# Altium schematic sheet 06_HDMI_I.SchDoc
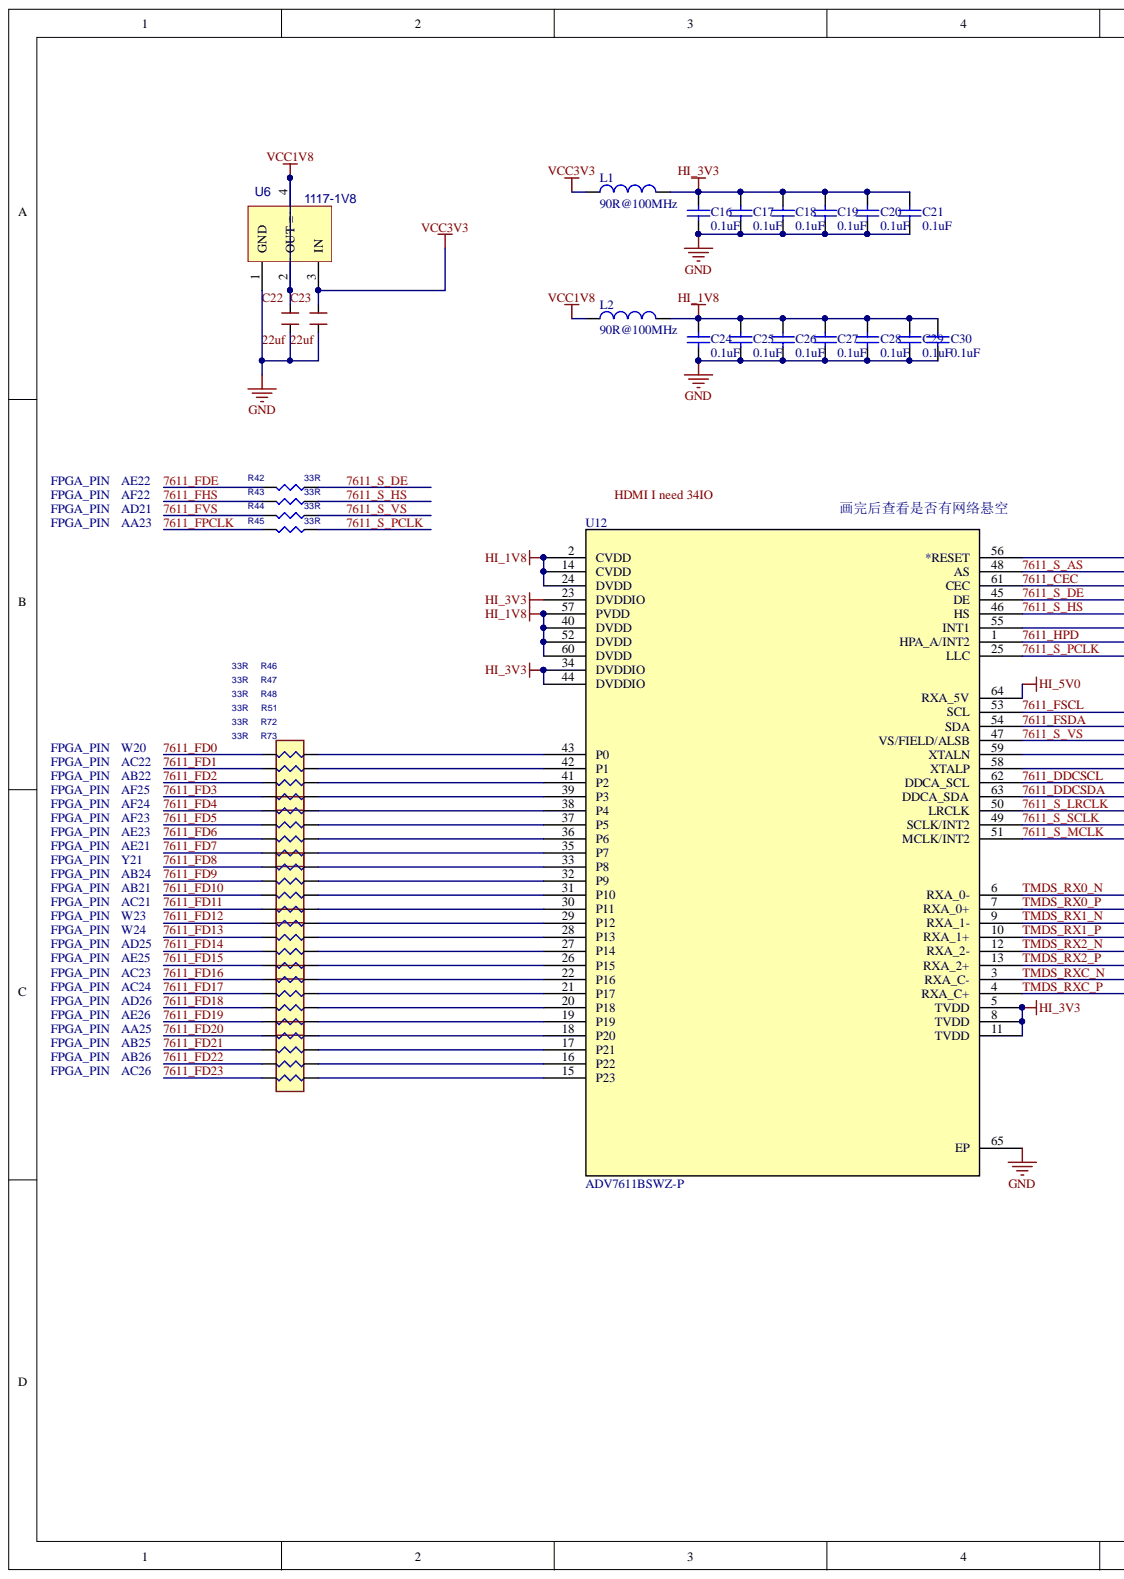
[diagram p0: 06_HDMI_I.SchDoc, left]
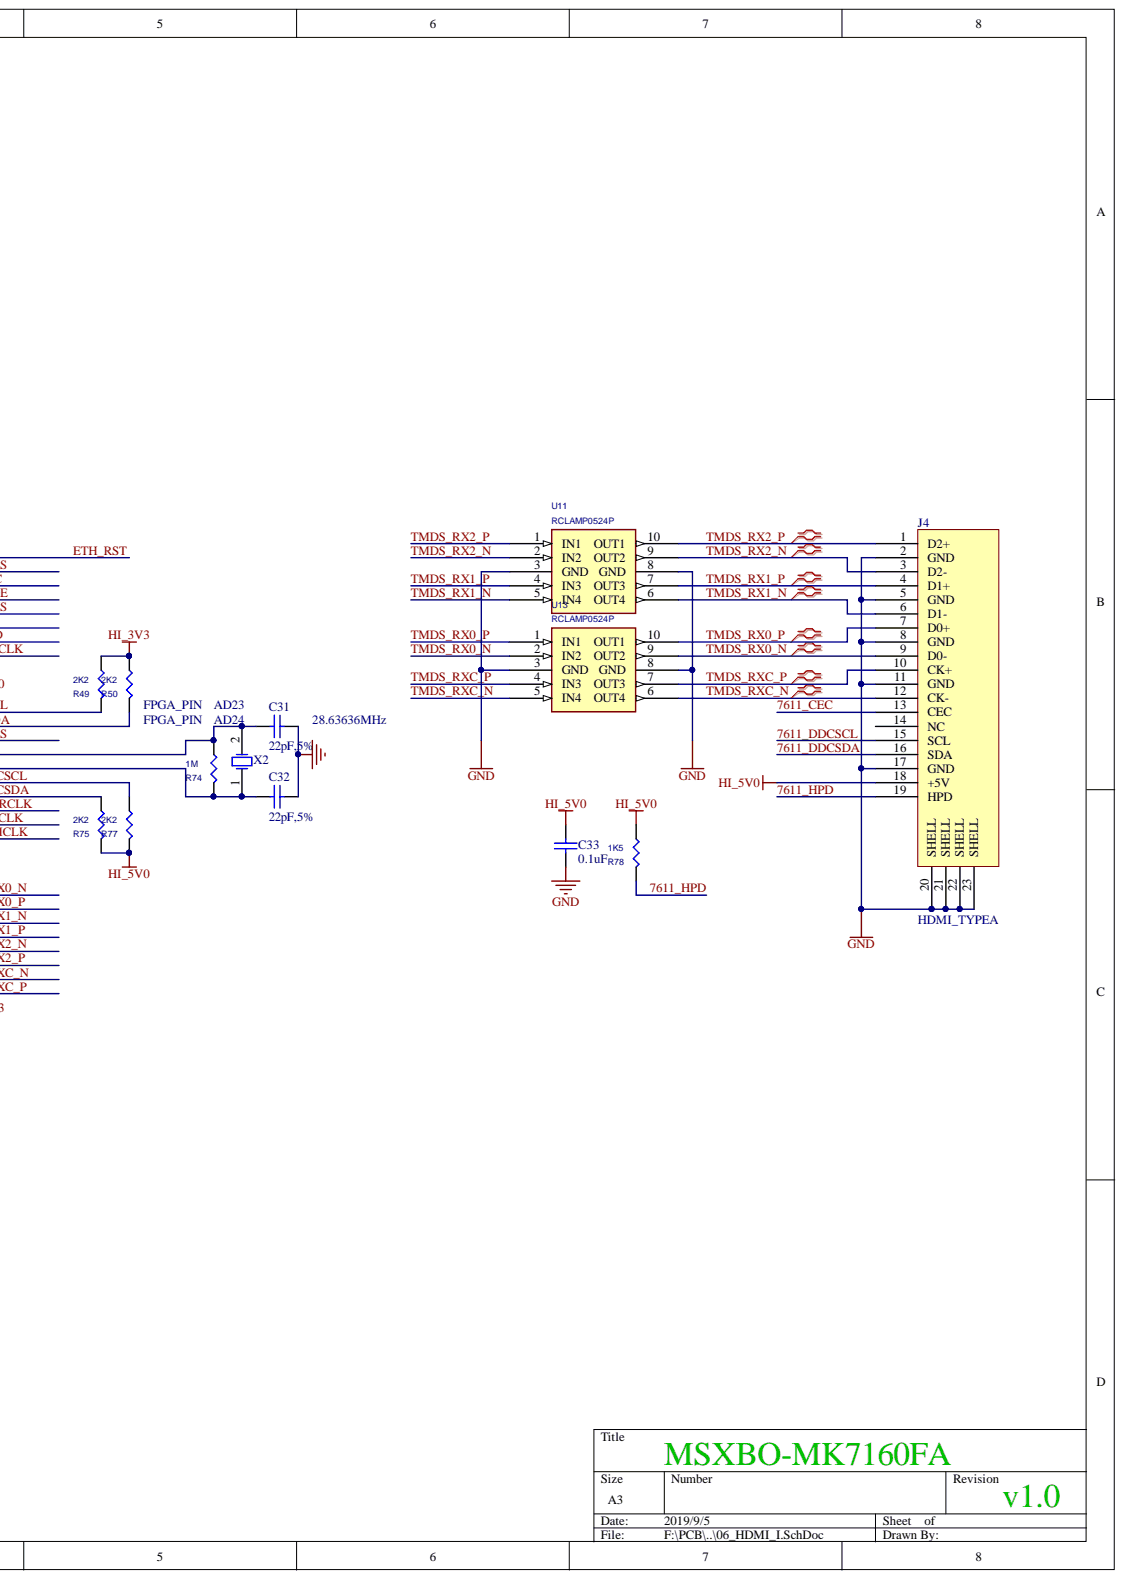
[diagram p1: 06_HDMI_I.SchDoc, right]

## Parts
C16  0.1uF  [CAP0402]
C17  0.1uF  [CAP0402]
C18  0.1uF  [CAP0402]
C19  0.1uF  [CAP0402]
C20  0.1uF  [CAP0402]
C21  0.1uF  [CAP0402]
C22  22uf  [CAP0805]
C23  22uf  [CAP0805]
C24  0.1uF  [CAP0402]
C25  0.1uF  [CAP0402]
C26  0.1uF  [CAP0402]
C27  0.1uF  [CAP0402]
C28  0.1uF  [CAP0402]
C29  0.1uF  [CAP0402]
C30  0.1uF  [CAP0402]
C31  22pF,5%  [CAP0402]
C32  22pF,5%  [CAP0402]
C33  0.1uF  [CAP0402]
J4  HDMI_TYPEA  [HDMI_SMD_A]
L1  90R@100MHz  [R0603]
L2  90R@100MHz  [R0603]
R42  33R  [R0402]
R43  33R  [R0402]
R44  33R  [R0402]
R45  33R  [R0402]
R46  33R  [RA4_0402]
R47  33R  [RA4_0402]
R48  33R  [RA4_0402]
R49  2K2  [R0402]
R50  2K2  [R0402]
R51  33R  [RA4_0402]
R72  33R  [RA4_0402]
R73  33R  [RA4_0402]
R74  1M  [R0402]
R75  2K2  [R0402]
R77  2K2  [R0402]
R78  1K5  [R0402]
U6  1117-1V8  [SOT223]
U11  RCLAMP0524P  [SLP2510P8_10]
U12  ADV7611BSWZ-P  [ADV7513]
U13  RCLAMP0524P  [SLP2510P8_10]
X2  28.63636MHz  [CRY5032_2]

## Nets
GND: C16.2 C17.2 C18.2 C19.2 C20.2 C21.2 C22.2 C23.2 C24.2 C25.2 C26.2 C27.2 C28.2 C29.2 C30.2 C31.2 C32.2 C33.2 J4.2(GND) J4.5(GND) J4.8(GND) J4.11(GND) J4.17(GND) J4.20(SHELL) J4.21(SHELL) J4.22(SHELL) J4.23(SHELL) U6.1(GND) U11.3(GND) U11.8(GND) U12.65(EP) U13.3(GND) U13.8(GND)
HI_1V8: C24.1 C25.1 C26.1 C27.1 C28.1 C29.1 C30.1 L2.2 U12.2(CVDD) U12.14(CVDD) U12.24(DVDD) U12.40(DVDD) U12.52(DVDD) U12.57(PVDD) U12.60(DVDD)
HI_3V3: C16.1 C17.1 C18.1 C19.1 C20.1 C21.1 L1.2 R49.2 R50.2 U12.5(TVDD) U12.8(TVDD) U12.11(TVDD) U12.23(DVDDIO) U12.34(DVDDIO) U12.44(DVDDIO)
HI_5V0: C33.1 J4.18(+5V) R75.1 R77.1 R78.2 U12.64(RXA_5V)
VCC1V8: C22.1 L2.1 U6.2(OUT) U6.4(=)
VCC3V3: C23.1 L1.1 U6.3(IN)
7611_CEC: J4.13(CEC) U12.61(CEC)
7611_DDCSCL: J4.15(SCL) R77.2 U12.62(DDCA_SCL)
7611_DDCSDA: J4.16(SDA) R75.2 U12.63(DDCA_SDA)
7611_FD0: R47.8
7611_FD1: R47.7
7611_FD2: R47.6
7611_FD3: R47.5
7611_FD4: R46.8
7611_FD5: R46.7
7611_FD6: R46.6
7611_FD7: R46.5
7611_FD8: R48.8
7611_FD9: R48.7
7611_FD10: R48.6
7611_FD11: R48.5
7611_FD12: R51.8
7611_FD13: R51.7
7611_FD14: R51.6
7611_FD15: R51.5
7611_FD16: R72.8
7611_FD17: R72.7
7611_FD18: R72.6
7611_FD19: R72.5
7611_FD20: R73.8
7611_FD21: R73.7
7611_FD22: R73.6
7611_FD23: R73.5
7611_FDE: R42.1
7611_FHS: R43.1
7611_FPCLK: R45.1
7611_FSCL: R49.1 U12.53(SCL)
7611_FSDA: R50.1 U12.54(SDA)
7611_FVS: R44.1
7611_HPD: J4.19(HPD) R78.1 U12.1(HPA_A/INT2)
7611_S_AS: U12.48(AS)
7611_S_DE: R42.2 U12.45(DE)
7611_S_HS: R43.2 U12.46(HS)
7611_S_LRCLK: U12.50(LRCLK)
7611_S_MCLK: U12.51(MCLK/INT2)
7611_S_PCLK: R45.2 U12.25(LLC)
7611_S_SCLK: U12.49(SCLK/INT2)
7611_S_VS: R44.2 U12.47(VS/FIELD/ALSB)
ETH_RST: U12.56(*RESET)
TMDS_RX0_N: J4.9(D0-) U12.6(RXA_0-) U13.2(IN2) U13.9(OUT2)
TMDS_RX0_P: J4.7(D0+) U12.7(RXA_0+) U13.1(IN1) U13.10(OUT1)
TMDS_RX1_N: J4.6(D1-) U11.5(IN4) U11.6(OUT4) U12.9(RXA_1-)
TMDS_RX1_P: J4.4(D1+) U11.4(IN3) U11.7(OUT3) U12.10(RXA_1+)
TMDS_RX2_N: J4.3(D2-) U11.2(IN2) U11.9(OUT2) U12.12(RXA_2-)
TMDS_RX2_P: J4.1(D2+) U11.1(IN1) U11.10(OUT1) U12.13(RXA_2+)
TMDS_RXC_N: J4.12(CK-) U12.3(RXA_C-) U13.5(IN4) U13.6(OUT4)
TMDS_RXC_P: J4.10(CK+) U12.4(RXA_C+) U13.4(IN3) U13.7(OUT3)
NetC31_1: C31.1 R74.2 U12.59(XTALN) X2.2
NetC32_1: C32.1 R74.1 U12.58(XTALP) X2.1
NetR46_1: R46.1 U12.38(P4)
NetR46_2: R46.2 U12.37(P5)
NetR46_3: R46.3 U12.36(P6)
NetR46_4: R46.4 U12.35(P7)
NetR47_1: R47.1 U12.43(P0)
NetR47_2: R47.2 U12.42(P1)
NetR47_3: R47.3 U12.41(P2)
NetR47_4: R47.4 U12.39(P3)
NetR48_1: R48.1 U12.33(P8)
NetR48_2: R48.2 U12.32(P9)
NetR48_3: R48.3 U12.31(P10)
NetR48_4: R48.4 U12.30(P11)
NetR51_1: R51.1 U12.29(P12)
NetR51_2: R51.2 U12.28(P13)
NetR51_3: R51.3 U12.27(P14)
NetR51_4: R51.4 U12.26(P15)
NetR72_1: R72.1 U12.22(P16)
NetR72_2: R72.2 U12.21(P17)
NetR72_3: R72.3 U12.20(P18)
NetR72_4: R72.4 U12.19(P19)
NetR73_1: R73.1 U12.18(P20)
NetR73_2: R73.2 U12.17(P21)
NetR73_3: R73.3 U12.16(P22)
NetR73_4: R73.4 U12.15(P23)
FPGA_PIN:
HDMI I NEED 34IO:

Not connected: J4 14(NC); U12 55(INT1)

## Library data of the parts
C16-C21 C24-C30 C33 = Cap: Capacitor; Component Kind: Standard; LatestRevisionDate: 17-Jul-2002; LatestRevisionNote: Re-released for DXP Platform.; Library Name: Miscellaneous Devices.IntLib; PackageDescription: Radial Cap, Thru-Hole; 2 Leads; 0.3 in Pin Spacing; PackageReference: RAD-0.3; Published: 8-Jun-2000; Signal Integrity: Cap; Simulation: CAP; Value: 0.1uF; Publisher: Altium Limited
C22 C23 = CAPACITOR: Generic Capacitor; Value: 0.1uF; Component Kind: Standard; Library Name: Digilent.IntLib
C31 C32 = Cap: Capacitor; Value: 100pF; Component Kind: Standard; Library Name: Miscellaneous Devices.IntLib; Published: 8-Jun-2000; Revision: July-2002: Re-released for DXP Platform.; Signal Integrity: Cap; Simulation: CAP; Publisher: Altium Limited
L1 L2 = Inductor: Value: 10mH; Component Kind: Standard; Description: Inductor; Published: 8-Jun-2000; Revision: July-2002: Re-released for DXP Platform.; Signal Integrity: Inductor; Simulation: INDUCTOR; Library Name: scalerboard.SchLib; Publisher: Altium Limited
R42-R45 R49 R50 R74 R75 R77 R78 = RES1: DIST: FLAT; MAX_TEMP: RTMAX; POWER: RMAX; PSpiceTemplate: R^@REFDES %1 %2 ?TOLERANCE|R^@REFDES| @VALUE TC=@TC1,@TC2 ?TOLERANCE|\n.model R^@REFDES RES R=1 DEV=@TOLERANCE% TC1=@TC1 TC2=@TC2|; SLOPE: RSMAX; TC1: 0; VOLTAGE: RVMAX; Component Kind: Standard; Simulation: RES1; TC2: 0
  R42-R45: Value: 33R
  R49 R50 R75 R77: Value: 2K2
  R74: Value: 1M
  R78: Value: 1K5
R46-R48 R51 R72 R73 = RA-4: REUSE_ANNOTATE: TRUE; REUSE_ID: 202; Component Kind: Standard
U6 = 1117-3.3: SMD_SOT223; Component Kind: Standard; Library Name: eddy_sch_lib.SchLib
U12 = ADV7611BSWZ-P: Imported; Component Kind: Standard; Library Name: A7_Fun_Library.SchLib; RefDes: RefDes; Type: DEV; Value: Value
X2 = XTAL: Crystal Oscillator; Component Kind: Standard; Library Name: Miscellaneous Devices.IntLib; Published: 8-Jun-2000; Revision: July-2002: Re-released for DXP Platform.; Simulation: XTAL; Publisher: Altium Limited
J4 = HDMI_TYPEA: Component Kind: Standard; Library Name: DSP.SchLib
U11 U13 = RCLAMP0524P: Component Kind: Standard
